# Altium schematic sheet [1] Power Circuit.SchDoc
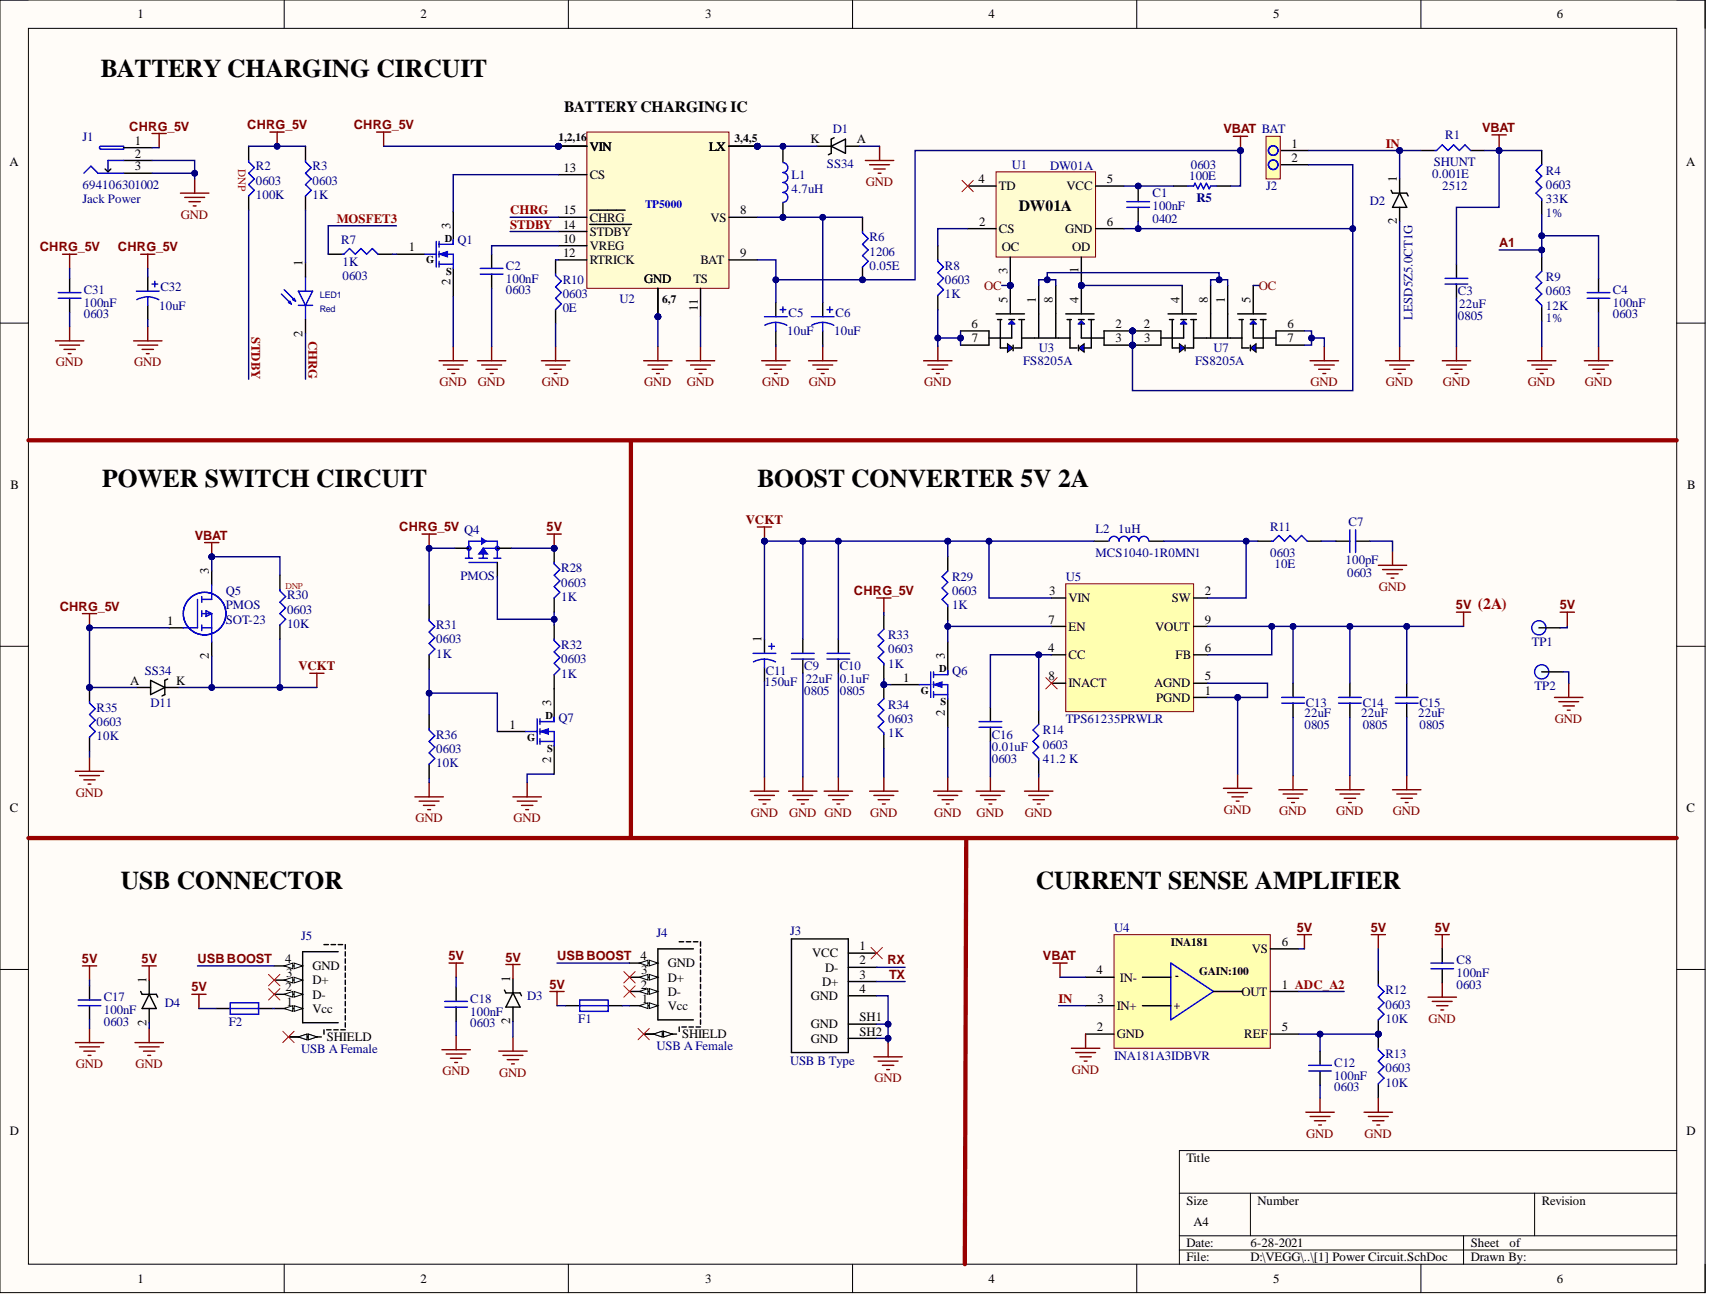
[diagram p0: [1] Power Circuit.SchDoc]

## Parts
C1  value 100nF  Case/Package: 0402  [CAPC0402]  (hidden comment: Cap)
C2  value 100nF  Case/Package: 0603  [CAPC0603]  (hidden comment: Cap)
C3  value 22uF  Case/Package: 0805  [CAPC0805_A14]  (hidden comment: Cap)
C4  value 100nF  Case/Package: 0603  [RESC0603]  (hidden comment: Cap)
C5  value 10uF  [CASE-A_3216]  (hidden comment: Cap Pol)
C6  value 10uF  [CASE-A_3216]  (hidden comment: Cap Pol)
C7  value 100pF  Case/Package: 0603  [RESC0603]  (hidden comment: Cap)
C8  value 100nF  Case/Package: 0603  [RESC0603]  (hidden comment: Cap)
C9  value 22uF  Case/Package: 0805  [CAPC0805_A14]  (hidden comment: Cap)
C10  value 0.1uF  Case/Package: 0805  [CAPC0805_A14]  (hidden comment: Cap)
C11  value 150uF  [CASE-D_7343]  (hidden comment: Tantalum capacitor)
C12  value 100nF  Case/Package: 0603  [RESC0603]  (hidden comment: Cap)
C13  value 22uF  Case/Package: 0805  [CAPC0805_A14]  (hidden comment: Cap)
C14  value 22uF  Case/Package: 0805  [CAPC0805_A14]  (hidden comment: Cap)
C15  value 22uF  Case/Package: 0805  [CAPC0805_A14]  (hidden comment: Cap)
C16  value 0.01uF  Case/Package: 0603  [CAPC0805_A14]  (hidden comment: Cap)
C17  value 100nF  Case/Package: 0603  [CAPC0603]  (hidden comment: Cap)
C18  value 100nF  Case/Package: 0603  [CAPC0603]  (hidden comment: Cap)
C31  value 100nF  Case/Package: 0603  [CAPC0603]  (hidden comment: Cap)
C32  value 10uF  [CASE-A_3216]  (hidden comment: Cap Pol)
D1  SS34  [SMA]
D2  LESD5Z5.0CT1G  [SOD-923]
D3  LESD5Z5.0CT1G  Operating Supply Voltage: 5V  [SOD-923]
D4  LESD5Z5.0CT1G  Operating Supply Voltage: 5V  [SOD-923]
D11  SS34  [SMA]
F1  SMD1206B200TF  [SMD1206B200TF]  (value not drawn)
F2  SMD1206B200TF  [SMD1206B200TF]  (value not drawn)
J1  Manufacturer Part Number: 694106301002  [12V_PWR JACK]  (hidden comment: JACK POWER)
J2  BAT  [HDR1X2H]  (no BOM)
J3  USB B Type  value USB Type B  [USB B TYPE]
J4  USB A Female  [USB A FEMALE]
J5  USB A Female  [USB A FEMALE]
L1  value 4.7uH  [SMD,6x6x4.2mm]  (hidden comment: Inductor)
L2  value 1uH  Manufacturer Part Number: MCS1040-1R0MN1  [10.0*11.5*4.0]  (hidden comment: Inductor)
LED1  Red  [LED-1 - horizontal]
Q1  MOSFET-N Si2302CDS  [SOT95P240X115-3N]  (value not drawn)
Q4  PMOS
Q5  PMOS  Case/Package: SOT-23  [SOT23-3]
Q6  MOSFET-N Si2302CDS  [SOT95P240X115-3N]  (value not drawn)
Q7  MOSFET-N Si2302CDS  [SOT95P240X115-3N]  (value not drawn)
R1  SHUNT  value 0.001E  [RESC2512]
R2  DNP  value 100K  Case/Package: 0603  [RESC0603]
R3  value 1K  Case/Package: 0603  [RESC0603]  (hidden comment: Res)
R4  value 33K  Case/Package: 0603  Parameter 1: 1%  [resc0603]  (hidden comment: Res)
R5  value 100E  Case/Package: 0603  [RESC0603]  (hidden comment: Res1)
R6  value 0.05E  Case/Package: 1206  [RESC1206]  (hidden comment: Res)
R7  value 1K  [RESC0603]  (hidden comment: Res)
R8  value 1K  Case/Package: 0603  [RESC0603]  (hidden comment: Res)
R9  value 12K  Case/Package: 0603  Parameter 1: 1%  [resc0603]  (hidden comment: Res)
R10  value 0E  Case/Package: 0603  [RESC0603]  (hidden comment: Res)
R11  value 10E  Case/Package: 0603  [RESC0603]  (hidden comment: Res)
R12  value 10K  Case/Package: 0603  [RESC0603]  (hidden comment: Res)
R13  value 10K  Case/Package: 0603  [RESC0603]  (hidden comment: Res)
R14  value 41.2 K  Case/Package: 0603  [RESC0603]  (hidden comment: Res)
R28  value 1K  Case/Package: 0603  [RESC0603]  (hidden comment: Res)
R29  value 1K  Case/Package: 0603  [RESC0603]  (hidden comment: Res)
R30  DNP  value 10K  Case/Package: 0603  [RESC0603]
R31  value 1K  Case/Package: 0603  [RESC0603]  (hidden comment: Res)
R32  value 1K  Case/Package: 0603  [RESC0603]  (hidden comment: Res)
R33  value 1K  Case/Package: 0603  [RESC0603]  (hidden comment: Res)
R34  value 1K  Case/Package: 0603  [RESC0603]  (hidden comment: Res)
R35  value 10K  Case/Package: 0603  [RESC0603]  (hidden comment: Res)
R36  value 10K  Case/Package: 0603  [RESC0603]  (hidden comment: Res)
TP1  TP  [TP-1]  (no BOM)
TP2  TP  [TP-1]  (no BOM)
U1  DW01A  [SOT-23-6]
U2  TP5000  [QFN-16_4x4x065P]
U3  FS8205A  [TSSOP8]
U4  INA181A3IDBVR  [SOT-23-6]
U5  TPS61235PRWLR  [TPS61235PRWLR]
U7  FS8205A  [TSSOP8]

## Nets
5V: C8.1 C13.2 C14.2 C15.2 C17.1 C18.1 D3.1 D4.1 F1.1 F2.1 Q4.S R12.1 R28.1 TP1.1 U4.6(VS) U5.6(FB) U5.9(VOUT)
CHRG_5V: C31.1 C32.1 D11.A J1.1 Q4.D Q5.1 R2.1 R3.1 R31.1 R33.2 R35.1 U2.1(VIN) U2.2 U2.16
GND: C2.2 C3.1 C4.2 C5.2 C6.2 C7.1 C8.2 C9.1 C10.1 C11.2 C12.2 C13.1 C14.1 C15.1 C16.1 C17.2 C18.2 C31.2 C32.2 D1.A D2.2 D3.2 D4.2 J1.2 J1.3 J3.4(GND) J3.SH1(GND) J3.SH2(GND) Q1.2 Q6.2 Q7.2 R8.2 R9.1 R10.2 R13.2 R14.1 R34.1 R35.2 R36.2 TP2.1 U2.6 U2.7 U2.11(TS) U3.6 U3.7 U4.2(GND) U5.1(PGND) U5.5(AGND) U7.6 U7.7
VBAT: C3.2 C5.1 Q5.3 R1.2 R4.2 R5.2 R6.2 R30.1 U2.9(BAT) U4.4(IN-)
VCKT: C9.2 C10.2 C11.1 D11.K L2.1 Q5.2 R29.2 R30.2 U5.3(VIN)
A1: C4.1 R4.1 R9.2
ADC_A2: U4.1(OUT)
CHRG: LED1.2 U2.15(CHRG)
IN: D2.1 J2.1 R1.1 U4.3(IN+)
MOSFET3: R7.1
OC: U1.3(OC) U3.5 U7.5
RX: J3.2(D-)
STDBY: R2.2 U2.14(STDBY)
TX: J3.3(D+)
USB BOOST: J4.4(GND) J5.4(GND)
NetC1_1: C1.1 R5.1 U1.5(VCC)
NetC1_2: C1.2 J2.2 U1.6(GND) U3.2 U3.3 U7.2 U7.3
NetC2_1: C2.1 U2.10(VREG)
NetC6_1: C6.1 L1.2 R6.1 U2.8(VS)
NetC7_2: C7.2 R11.2
NetC12_1: C12.1 R12.2 R13.1 U4.5(REF)
NetC16_2: C16.2 R14.2 U5.4(CC)
NetD1_K: D1.K L1.1 U2.3(LX) U2.4 U2.5
NetF1_2: F1.2 J4.1(Vcc)
NetF2_2: F2.2 J5.1(Vcc)
NetL2_2: L2.2 R11.1 U5.2(SW)
NetLED1_1: LED1.1 R3.2
NetQ1_1: Q1.1 R7.2
NetQ1_3: Q1.3 U2.13(CS)
NetQ4_G: Q4.G R28.2 R32.1
NetQ6_1: Q6.1 R33.1 R34.2
NetQ6_3: Q6.3 R29.1 U5.7(EN)
NetQ7_1: Q7.1 R31.2 R36.1
NetQ7_3: Q7.3 R32.2
NetR8_1: R8.1 U1.2(CS)
NetR10_1: R10.1 U2.12(RTRICK)
NetU1_1: U1.1(OD) U3.4 U7.4
NetU3_1: U3.1 U3.8 U7.1 U7.8

Not connected: J3 1(VCC); J4 2(D-) 3(D+) SHELL; J5 2(D-) 3(D+) SHELL; U1 4(TD); U5 8(INACT)

## Library data of the parts
C1 = Cap: Ceramic Capacitor,100nF,0402; Manufacturer Part Number: 04025C104KAT2A; Component Kind: Standard; Library Name: CUSTOM TNY290PG.SchLib; Signal Integrity: Cap Pol; Simulation: CAP
C2 C4 C8 C12 C17 C18 C31 = Cap: Ceramic Capacitor,100nF,10V,0603; Manufacturer Part Number: CC0603KRX7R6BB104; Component Kind: Standard; ComponentLink1Description: http://www.farnell.com/datasheets/2739827.pdf?src-supplier=Element14; ComponentLink1URL: http://www.farnell.com/datasheets/2739827.pdf?src-supplier=Element14; ComponentLink2Description: http://www.farnell.com/datasheets/40889.pdf?src-supplier=Element14; ComponentLink2URL: http://www.farnell.com/datasheets/40889.pdf?src-supplier=Element14; Library Name: CUSTOM TNY290PG.SchLib; Signal Integrity: Cap Pol; Simulation: CAP
C3 C9 C13-C15 = Cap: Ceramic Capacitor,22uF,10V,0805,X5R; Manufacturer Part Number: 0805X226M100NT; Component Kind: Standard; ComponentLink1Description: http://www.farnell.com/datasheets/2739827.pdf?src-supplier=Element14; ComponentLink1URL: http://www.farnell.com/datasheets/2739827.pdf?src-supplier=Element14; ComponentLink2Description: http://www.farnell.com/datasheets/40889.pdf?src-supplier=Element14; ComponentLink2URL: http://www.farnell.com/datasheets/40889.pdf?src-supplier=Element14; Library Name: CUSTOM TNY290PG.SchLib; Signal Integrity: Cap Pol; Simulation: CAP
C5 C6 C32 = Cap Pol: Tantalum capacitor 10uF 10V, CASE-A_3216; Manufacturer Part Number: CA45-106K010AT; Case/Package: CASE-A_3216; Component Kind: Standard; Library Name: CUSTOM TNY290PG.SchLib; Signal Integrity: Cap Pol; Simulation: CAP
C7 = Cap: Ceramic Capacitor,100pF,50V,C0G/NP0,0603; Manufacturer Part Number: CGA3E2C0G1H101JT0Y0N; Component Kind: Standard; ComponentLink1Description: http://www.farnell.com/datasheets/2739827.pdf?src-supplier=Element14; ComponentLink1URL: http://www.farnell.com/datasheets/2739827.pdf?src-supplier=Element14; ComponentLink2Description: http://www.farnell.com/datasheets/40889.pdf?src-supplier=Element14; ComponentLink2URL: http://www.farnell.com/datasheets/40889.pdf?src-supplier=Element14; Library Name: CUSTOM TNY290PG.SchLib; Signal Integrity: Cap Pol; Simulation: CAP
C10 = Cap: Ceramic Capacitor,0.1uF,25V,0805,X5R; Manufacturer Part Number: 0402X104K250CT; Component Kind: Standard; ComponentLink1Description: http://www.farnell.com/datasheets/2739827.pdf?src-supplier=Element14; ComponentLink1URL: http://www.farnell.com/datasheets/2739827.pdf?src-supplier=Element14; ComponentLink2Description: http://www.farnell.com/datasheets/40889.pdf?src-supplier=Element14; ComponentLink2URL: http://www.farnell.com/datasheets/40889.pdf?src-supplier=Element14; Library Name: CUSTOM TNY290PG.SchLib; Signal Integrity: Cap Pol; Simulation: CAP
C11 = Cap Pol: Tantalum capacitor 150uF 10V,  CASE-D_7343; Manufacturer Part Number: T495D157K010ATE100/TPSC157K010R0150; Case/Package: CASE-D_7343; Component Kind: Standard; Library Name: CUSTOM TNY290PG.SchLib; Signal Integrity: Cap Pol; Simulation: CAP
C16 = Cap: Ceramic Capacitor,0.01uF,6.3V,0603,X5R; Component Kind: Standard; ComponentLink1Description: http://www.farnell.com/datasheets/2739827.pdf?src-supplier=Element14; ComponentLink1URL: http://www.farnell.com/datasheets/2739827.pdf?src-supplier=Element14; ComponentLink2Description: http://www.farnell.com/datasheets/40889.pdf?src-supplier=Element14; ComponentLink2URL: http://www.farnell.com/datasheets/40889.pdf?src-supplier=Element14; Library Name: CUSTOM TNY290PG.SchLib; Signal Integrity: Cap Pol; Simulation: CAP
D1 D11 = SS34: 40V 3A 550mV @ 3A SMA Schottky Barrier Diodes (SBD) RoHS; Case/Package: SMA; Component Kind: Standard; ComponentLink1Description: https://datasheet.ciiva.com/30478/0900766b812769b5-30478676.pdf?src-supplier=RSComponents; ComponentLink1URL: https://datasheet.ciiva.com/30478/0900766b812769b5-30478676.pdf?src-supplier=RSComponents; ComponentLink2Description: https://datasheet.ciiva.com/25958/esd9x3-3st5g-d-25958458.pdf?src-supplier=Avnet+Europe; ComponentLink2URL: https://datasheet.ciiva.com/25958/esd9x3-3st5g-d-25958458.pdf?src-supplier=Avnet+Europe; ComponentLink3Description: https://datasheet.ciiva.com/1219/1748783-1219324.pdf?src-supplier=Newark; ComponentLink3URL: https://datasheet.ciiva.com/1219/1748783-1219324.pdf?src-supplier=Newark; ComponentLink4Description: https://datasheet.ciiva.com/3304/esd9x3-3st5g-d-3304012.pdf?src-supplier=Avnet; ComponentLink4URL: https://datasheet.ciiva.com/3304/esd9x3-3st5g-d-3304012.pdf?src-supplier=Avnet; ComponentLink5Description: https://datasheet.ciiva.com/339/esd9x3-3st5g-d-339709.pdf?src-supplier=Digi-Key; ComponentLink5URL: https://datasheet.ciiva.com/339/esd9x3-3st5g-d-339709.pdf?src-supplier=Digi-Key; ComponentLink6Description: https://datasheet.ciiva.com/16215/esd9x3-3st5g-d-16215149.pdf?src-supplier=Future+Electronics; ComponentLink6URL: https://datasheet.ciiva.com/16215/esd9x3-3st5g-d-16215149.pdf?src-supplier=Future+Electronics; ComponentLink7Description: https://datasheet.ciiva.com/339/esd9x3-3st5g-d-339710.pdf?src-supplier=Digi-Key; ComponentLink7URL: https://datasheet.ciiva.com/339/esd9x3-3st5g-d-339710.pdf?src-supplier=Digi-Key; ComponentLink8Description: https://datasheet.ciiva.com/339/esd9x3-3st5g-d-339711.pdf?src-supplier=Digi-Key; ComponentLink8URL: https://datasheet.ciiva.com/339/esd9x3-3st5g-d-339711.pdf?src-supplier=Digi-Key; Library Name: CUSTOM TNY290PG.SchLib; Manufacturer Part Number: SS34; Value: SS34
D2 = ESD9X5.0ST5G: 15V Clamp 5A (8/20µs) Ipp Tvs Diode Surface Mount SOD-523; Manufacturer: ON Semiconductor; Breakdown Voltage: 6.2V; Capacitance: 65pF; Clamping Voltage: 12.3V; Direction: Unidirectional; Element Configuration: Single; ESD Protection: Yes; Leakage Current: 1uA; Max Power Dissipation: 150mW; Max Surge Current: 8.7A; Number of Channels: 1; Operating Supply Voltage: 5V; Peak Pulse Power: 107W; Power Line Protection: No; Test Current: 1mA; Case/Package: SOD-523-2; Category: ESD and Circuit Protection ICs; Component Kind: Standard; ComponentLink1Description: https://datasheet.ciiva.com/30478/0900766b812769b5-30478676.pdf?src-supplier=RSComponents; ComponentLink1URL: https://datasheet.ciiva.com/30478/0900766b812769b5-30478676.pdf?src-supplier=RSComponents; ComponentLink2Description: https://datasheet.ciiva.com/25958/esd9x3-3st5g-d-25958458.pdf?src-supplier=Avnet+Europe; ComponentLink2URL: https://datasheet.ciiva.com/25958/esd9x3-3st5g-d-25958458.pdf?src-supplier=Avnet+Europe; ComponentLink3Description: https://datasheet.ciiva.com/1219/1748783-1219324.pdf?src-supplier=Newark; ComponentLink3URL: https://datasheet.ciiva.com/1219/1748783-1219324.pdf?src-supplier=Newark; ComponentLink4Description: https://datasheet.ciiva.com/3304/esd9x3-3st5g-d-3304012.pdf?src-supplier=Avnet; ComponentLink4URL: https://datasheet.ciiva.com/3304/esd9x3-3st5g-d-3304012.pdf?src-supplier=Avnet; ComponentLink5Description: https://datasheet.ciiva.com/339/esd9x3-3st5g-d-339709.pdf?src-supplier=Digi-Key; ComponentLink5URL: https://datasheet.ciiva.com/339/esd9x3-3st5g-d-339709.pdf?src-supplier=Digi-Key; ComponentLink6Description: https://datasheet.ciiva.com/16215/esd9x3-3st5g-d-16215149.pdf?src-supplier=Future+Electronics; ComponentLink6URL: https://datasheet.ciiva.com/16215/esd9x3-3st5g-d-16215149.pdf?src-supplier=Future+Electronics; ComponentLink7Description: https://datasheet.ciiva.com/339/esd9x3-3st5g-d-339710.pdf?src-supplier=Digi-Key; ComponentLink7URL: https://datasheet.ciiva.com/339/esd9x3-3st5g-d-339710.pdf?src-supplier=Digi-Key; ComponentLink8Description: https://datasheet.ciiva.com/339/esd9x3-3st5g-d-339711.pdf?src-supplier=Digi-Key; ComponentLink8URL: https://datasheet.ciiva.com/339/esd9x3-3st5g-d-339711.pdf?src-supplier=Digi-Key; Composition: Zener; Contact Plating: Tin,Matte; Depth: 0.6mm; Halogen Free: Halogen Free; Height: 0.4mm; Lead Free: Lead Free; Length: 0.8mm; Library Name: CUSTOM TNY290PG.SchLib; Manufacturer Part Number: LESD5Z5.0CT1G; Max Breakdown Voltage: 6.2V; Max Operating Temperature: 150°C; Max Reverse Leakage Current: 1uA; Min Breakdown Voltage: 6.2V; Min Operating Temperature: -55°C; Number of Elements: 1; Number of Lines: 1; Number of Pins: 2; Number of Unidirectional Channels: 1; Package Quantity: 1; Packaging: Tape and Reel; Peak Pulse Current: 8.7A; Polarity: Unidirectional; Pricing 1: 1=0.14000, 10=0.12700, 25=0.12120, 100=0.05340, 250=0.05120, 500=0.04190, 1000=0.02910, 2500=0.02542 (USD); Radiation Hardening: No; REACH SVHC: No SVHC; Reverse Breakdown Voltage: 6.2V; Reverse Standoff Voltage: 5V; RoHS: Yes; Stock 1: 835133; Supplier 1: Digi-Key; Supplier Part Number 1: ESD9X5.0ST5GOSCT-ND; Termination: SMD/SMT; Width: 0.65mm; Working Voltage: 5V
D3 D4 = ESD9X5.0ST5G: 15V Clamp 5A (8/20µs) Ipp Tvs Diode Surface Mount SOD-523; Manufacturer: ON Semiconductor; Breakdown Voltage: 6.2V; Capacitance: 65pF; Clamping Voltage: 12.3V; Direction: Unidirectional; Element Configuration: Single; ESD Protection: Yes; Leakage Current: 1uA; Max Power Dissipation: 150mW; Max Surge Current: 8.7A; Number of Channels: 1; Peak Pulse Power: 107W; Power Line Protection: No; Test Current: 1mA; Case/Package: SOD-523-2; Category: ESD and Circuit Protection ICs; Component Kind: Standard; ComponentLink1Description: https://datasheet.ciiva.com/30478/0900766b812769b5-30478676.pdf?src-supplier=RSComponents; ComponentLink1URL: https://datasheet.ciiva.com/30478/0900766b812769b5-30478676.pdf?src-supplier=RSComponents; ComponentLink2Description: https://datasheet.ciiva.com/25958/esd9x3-3st5g-d-25958458.pdf?src-supplier=Avnet+Europe; ComponentLink2URL: https://datasheet.ciiva.com/25958/esd9x3-3st5g-d-25958458.pdf?src-supplier=Avnet+Europe; ComponentLink3Description: https://datasheet.ciiva.com/1219/1748783-1219324.pdf?src-supplier=Newark; ComponentLink3URL: https://datasheet.ciiva.com/1219/1748783-1219324.pdf?src-supplier=Newark; ComponentLink4Description: https://datasheet.ciiva.com/3304/esd9x3-3st5g-d-3304012.pdf?src-supplier=Avnet; ComponentLink4URL: https://datasheet.ciiva.com/3304/esd9x3-3st5g-d-3304012.pdf?src-supplier=Avnet; ComponentLink5Description: https://datasheet.ciiva.com/339/esd9x3-3st5g-d-339709.pdf?src-supplier=Digi-Key; ComponentLink5URL: https://datasheet.ciiva.com/339/esd9x3-3st5g-d-339709.pdf?src-supplier=Digi-Key; ComponentLink6Description: https://datasheet.ciiva.com/16215/esd9x3-3st5g-d-16215149.pdf?src-supplier=Future+Electronics; ComponentLink6URL: https://datasheet.ciiva.com/16215/esd9x3-3st5g-d-16215149.pdf?src-supplier=Future+Electronics; ComponentLink7Description: https://datasheet.ciiva.com/339/esd9x3-3st5g-d-339710.pdf?src-supplier=Digi-Key; ComponentLink7URL: https://datasheet.ciiva.com/339/esd9x3-3st5g-d-339710.pdf?src-supplier=Digi-Key; ComponentLink8Description: https://datasheet.ciiva.com/339/esd9x3-3st5g-d-339711.pdf?src-supplier=Digi-Key; ComponentLink8URL: https://datasheet.ciiva.com/339/esd9x3-3st5g-d-339711.pdf?src-supplier=Digi-Key; Composition: Zener; Contact Plating: Tin,Matte; Depth: 0.6mm; Halogen Free: Halogen Free; Height: 0.4mm; Lead Free: Lead Free; Length: 0.8mm; Library Name: CUSTOM TNY290PG.SchLib; Manufacturer Part Number: LESD5Z5.0CT1G; Max Breakdown Voltage: 6.2V; Max Operating Temperature: 150°C; Max Reverse Leakage Current: 1uA; Min Breakdown Voltage: 6.2V; Min Operating Temperature: -55°C; Number of Elements: 1; Number of Lines: 1; Number of Pins: 2; Number of Unidirectional Channels: 1; Package Quantity: 1; Packaging: Tape and Reel; Peak Pulse Current: 8.7A; Polarity: Unidirectional; Pricing 1: 1=0.14000, 10=0.12700, 25=0.12120, 100=0.05340, 250=0.05120, 500=0.04190, 1000=0.02910, 2500=0.02542 (USD); Radiation Hardening: No; REACH SVHC: No SVHC; Reverse Breakdown Voltage: 6.2V; Reverse Standoff Voltage: 5V; RoHS: Yes; Stock 1: 835133; Supplier 1: Digi-Key; Supplier Part Number 1: ESD9X5.0ST5GOSCT-ND; Termination: SMD/SMT; Width: 0.65mm; Working Voltage: 5V
F1 F2 = FUSE: 6V 3.5A 1.5s SMD PTC Resettable Fuses RoHS; Case/Package: SMD; Component Kind: Standard; Library Name: Schlib1.SchLib; Manufacturer Part Number: SMD1206B200TF
J1 = JACK POWER: DC Power Connectors WR-DC Right Angled; Manufacturer: Wurth Electronics; Current Rating: 5A; Gender: Male; Insulation Resistance: 100MR; Shielding: Unshielded; Voltage Rating: 24V; Voltage Rating (DC): 20V; Case/Package: TH; Color: Black; Component Kind: Standard; ComponentLink1Description: https://katalog.we-online.de/em/datasheet/6941xx301002.pdf?src-supplier=Digi-Key; ComponentLink1URL: https://katalog.we-online.de/em/datasheet/6941xx301002.pdf?src-supplier=Digi-Key; ComponentLink2Description: http://www.farnell.com/cad/2130967.pdf?src-supplier=Element14; ComponentLink2URL: http://www.farnell.com/cad/2130967.pdf?src-supplier=Element14; ComponentLink3Description: http://www.mouser.com/ds/2/445/6941xx301002-469315.pdf?src-supplier=Mouser; ComponentLink3URL: http://www.mouser.com/ds/2/445/6941xx301002-469315.pdf?src-supplier=Mouser; Connector Type: Jack Power; Contact Gender: Female; Contact Material: Copper Alloy; Contact Plating: Tin; Flammability Rating: UL94 V-0; Housing Material: Polyamide; Library Name: CUSTOM TNY290PG.SchLib; Max Operating Temperature: 85°C; Min Operating Temperature: -40°C; Mount: Through Hole; Package Quantity: 500; Packaging: Bulk; Pricing 1: 1=0.96, 10=0.908, 50=0.811, 100=0.746, 500=0.648, 1000=0.551 (USD); REACH SVHC: Unknown; Stock 1: 3331; Supplier 1: Digi-Key; Supplier Part Number 1: 732-5930-ND; Termination: Through Hole
J2 = Header 2 pin: Library Name: CUSTOM TNY290PG.SchLib
J3 = USB B Type: USB B-type Female Right Angle PCB Mount; Case/Package: TH; Component Kind: Standard; Library Name: CUSTOM TNY290PG.SchLib; Manufacturer Part Number: Sunrom 3966
J4 J5 = USB A Female: USB-A (USB TYPE-A) USB 2.0 Receptacle Connector 4 Position Through Hole, Right Angle; Manufacturer: TE Connectivity; Manufacturer Part Number: 292303-1; Circuit Application: Signal; Connector System: Cable-to-Board; Contact Current Rating: 1A; Insulation Resistance: 1GR; Number of Contacts: 4; Number of Ports: 1; PCB Thickness: 0.062inch; Pitch: 2.5mm; Plating: Bright,Tin; Plating Thickness: 0.76um; Tail Length: 0.112inch; USB Standard: USB 2.0; Voltage Rating (AC): 30V; Case/Package: TH; Category: Audio / Video Connectors; Color: Black; Component Kind: Standard; ComponentLink1Description: https://datasheet.ciiva.com/851/eng-cd-292303-e9-851601.pdf?src-supplier=Farnell; ComponentLink1URL: https://datasheet.ciiva.com/851/eng-cd-292303-e9-851601.pdf?src-supplier=Farnell; ComponentLink2Description: https://datasheet.ciiva.com/1099/1653030-1099765.pdf?src-supplier=Newark; ComponentLink2URL: https://datasheet.ciiva.com/1099/1653030-1099765.pdf?src-supplier=Newark; ComponentLink3Description: https://datasheet.ciiva.com/1098/eng-cd-292303-e9-1098298.pdf?src-supplier=Element14; ComponentLink3URL: https://datasheet.ciiva.com/1098/eng-cd-292303-e9-1098298.pdf?src-supplier=Element14; ComponentLink4Description: https://datasheet.ciiva.com/11731/0900766b806d08c4-11731322.pdf?src-supplier=RSComponents; ComponentLink4URL: https://datasheet.ciiva.com/11731/0900766b806d08c4-11731322.pdf?src-supplier=RSComponents; ComponentLink5Description: https://datasheet.ciiva.com/14103/04270708-14103420.pdf?src-supplier=Distrelec; ComponentLink5URL: https://datasheet.ciiva.com/14103/04270708-14103420.pdf?src-supplier=Distrelec; ComponentLink6Description: https://datasheet.ciiva.com/19454/921651-19454494.pdf?src-supplier=Jameco; ComponentLink6URL: https://datasheet.ciiva.com/19454/921651-19454494.pdf?src-supplier=Jameco; ComponentLink7Description: https://datasheet.ciiva.com/16308/eng-cd-292303-e7-16308319.pdf?src-supplier=Future+Electronics; ComponentLink7URL: https://datasheet.ciiva.com/16308/eng-cd-292303-e7-16308319.pdf?src-supplier=Future+Electronics; ComponentLink8Description: https://datasheet.ciiva.com/3094/eng-ds-1-1773453-6-0908-3094357.pdf?src-supplier=Avnet; ComponentLink8URL: https://datasheet.ciiva.com/3094/eng-ds-1-1773453-6-0908-3094357.pdf?src-supplier=Avnet; ComponentLink9Description: https://datasheet.ciiva.com/16468/eng-ds-1-1773453-6-0908-16468232.pdf?src-supplier=Avnet+Asia; ComponentLink9URL: https://datasheet.ciiva.com/16468/eng-ds-1-1773453-6-0908-16468232.pdf?src-supplier=Avnet+Asia; ComponentLink10Description: https://datasheet.ciiva.com/851/eng-cd-292303-e9-851082.pdf?src-supplier=Digi-Key; ComponentLink10URL: https://datasheet.ciiva.com/851/eng-cd-292303-e9-851082.pdf?src-supplier=Digi-Key; ComponentLink11Description: https://datasheet.ciiva.com/8016/1653030-8016986.pdf?src-supplier=Element14; ComponentLink11URL: https://datasheet.ciiva.com/8016/1653030-8016986.pdf?src-supplier=Element14; ComponentLink12Description: https://datasheet.ciiva.com/14618/70041246-14618698.pdf?src-supplier=Allied+Electronics; ComponentLink12URL: https://datasheet.ciiva.com/14618/70041246-14618698.pdf?src-supplier=Allied+Electronics; ComponentLink13Description: https://datasheet.ciiva.com/25907/eng-ds-1-1773453-6-0908-25907515.pdf?src-supplier=Avnet+Europe; ComponentLink13URL: https://datasheet.ciiva.com/25907/eng-ds-1-1773453-6-0908-25907515.pdf?src-supplier=Avnet+Europe; ComponentLink14Description: https://datasheet.ciiva.com/30148/ddecontrolleractionsrchrtrvdocnm117734536doctypedsdoclan-30148862.pdf?src-supplier=Onlinecomponents.com; ComponentLink14URL: https://datasheet.ciiva.com/30148/ddecontrolleractionsrchrtrvdocnm117734536doctypedsdoclan-30148862.pdf?src-supplier=Onlinecomponents.com; Connector Type: Receptacle; Contact Material: Copper Alloy,Copper; Contact Plating: Gold; Current Rating: 1A; Depth: 14mm; Flammability Rating: UL94 V-0; Gender: Receptacle; Halogen Free: Halogen Free; Housing Color: Black; Housing Material: Thermoplastic; Length: 14.63mm; Library Name: CUSTOM TNY290PG.SchLib; Max Operating Temperature: 185°C; Min Operating Temperature: -67°C; Mount: Through Hole,Horizontal; Number of Positions: 4; Number of Terminals: 4; Orientation: Right Angle; Package Quantity: 30; Packaging: Rail/Tube; Pricing 1: 1=1.49000, 10=1.35400, 25=1.27160, 50=1.21620, 100=1.16100, 250=1.05040, 500=0.96748, 1000=0.82926, 2500=0.77398 (USD); REACH SVHC: No SVHC; RoHS: Yes; Stock 1: 16288; Supplier 1: Digi-Key; Supplier Part Number 1: A31726-ND; Termination: Through Hole
L1 = Inductor: 4.7uH ±20% 4A 26mΩ SMD,6x6x4.2mm Power Inductors RoHS; Manufacturer Part Number: HPC6045NF-4R7MTH; Case/Package: SMD,6x6x4.2mm; Component Kind: Standard; Library Name: CUSTOM TNY290PG.SchLib
L2 = Inductor: 1uH ±20% 18A 3mΩ 10.0*11.5*4.0 Power Inductors RoHS; Case/Package: 10.0*11.5*4.0; Component Kind: Standard; ComponentLink1Description: https://www.bourns.com/docs/Product-Datasheets/SRN6045.pdf?src-supplier=Digi-Key; ComponentLink1URL: https://www.bourns.com/docs/Product-Datasheets/SRN6045.pdf?src-supplier=Digi-Key; ComponentLink2Description: http://www.mouser.com/ds/2/54/rn6045-778135.pdf?src-supplier=Mouser; ComponentLink2URL: http://www.mouser.com/ds/2/54/rn6045-778135.pdf?src-supplier=Mouser; ComponentLink3Description: https://www.alliedelec.com/m/d/c2606704ad1546769e4d3e48849a9ce6.pdf?src-supplier=Allied+Electronics; ComponentLink3URL: https://www.alliedelec.com/m/d/c2606704ad1546769e4d3e48849a9ce6.pdf?src-supplier=Allied+Electronics; ComponentLink4Description: http://uk.rs-online.com/webdocs/0fe1/0900766b80fe1f3e.pdf?src-supplier=RSComponents; ComponentLink4URL: http://uk.rs-online.com/webdocs/0fe1/0900766b80fe1f3e.pdf?src-supplier=RSComponents; ComponentLink5Description: http://www.farnell.com/datasheets/1875285.pdf?src-supplier=Element14; ComponentLink5URL: http://www.farnell.com/datasheets/1875285.pdf?src-supplier=Element14; ComponentLink6Description: http://www.produktinfo.conrad.com/datenblaetter/1000000-1099999/001056444-da-01-en-MAG_ABG_LEIST_IND_SRN6045_1R0Y_1_0UH_SMD.pdf?src-supplier=Conrad; ComponentLink6URL: http://www.produktinfo.conrad.com/datenblaetter/1000000-1099999/001056444-da-01-en-MAG_ABG_LEIST_IND_SRN6045_1R0Y_1_0UH_SMD.pdf?src-supplier=Conrad; Library Name: CUSTOM TNY290PG.SchLib
LED1 = LED2: LED, Red, Untinted, Diffused; Manufacturer Part Number: KP DELPS1.FP-UGVI-34-Z555; Case/Package: TH; Component Kind: Standard; Stock 1: 0; Supplier 1: Mouser; Supplier Part Number 1: 720-KPDELPS1FPA3998
Q1 Q6 Q7 = MOSFET-N Si2302CDS: N-Channel 20V 3A 1.25W (Ta) Surface Mount SOT-23; Manufacturer: MCC; Manufacturer Part Number: SI2302-TP; Drain to Source Resistance: 110mR; Gate to Source Voltage (Vgs): 8V; Input Capacitance: 237pF; Number of Elements: 1; Rds On Max: 72mR; Case/Package: SOT-23; Component Kind: Standard; ComponentLink1Description: http://www.vishay.com/docs/68645/si2302cd.pdf?src-supplier=Avnet; ComponentLink1URL: http://www.vishay.com/docs/68645/si2302cd.pdf?src-supplier=Avnet; ComponentLink2Description: http://www.farnell.com/datasheets/1719572.pdf?src-supplier=Element14; ComponentLink2URL: http://www.farnell.com/datasheets/1719572.pdf?src-supplier=Element14; ComponentLink3Description: http://www.vishay.com/docs/68645/si2302cd.pdf?src-supplier=Future+Electronics; ComponentLink3URL: http://www.vishay.com/docs/68645/si2302cd.pdf?src-supplier=Future+Electronics; ComponentLink4Description: http://www.vishay.com/docs/68645/si2302cds.pdf?src-supplier=Digi-Key; ComponentLink4URL: http://www.vishay.com/docs/68645/si2302cds.pdf?src-supplier=Digi-Key; ComponentLink5Description: http://www.mccsemi.com/up_pdf/SI2302(SOT-23).pdf?src-supplier=Digi-Key; ComponentLink5URL: http://www.mccsemi.com/up_pdf/SI2302(SOT-23).pdf?src-supplier=Digi-Key; Continuous Drain Current (ID): 3A; Drain to Source Breakdown Voltage: 20V; Drain to Source Voltage (Vdss): 20V; Lead Free: Lead Free; Library Name: Miscellaneous Devices.SchLib; Max Operating Temperature: 150°C; Max Power Dissipation: 1.25W; Min Operating Temperature: -55°C; Mount: Surface Mount; Number of Pins: 3; Package Quantity: 3000; Packaging: Tape and Reel; Power Dissipation: 1.25W; Pricing 1: 1=0.45, 10=0.386, 100=0.288, 500=0.226, 1000=0.175, 3000=0.145 (USD); Simulation: NMOS; Stock 1: 29970; Supplier 1: Mouser; Supplier Part Number 1: 833-SI2302-TP
Q4 = MOSFET_P_CH: 12V 8A 1.2V @ 250uA 18mΩ @ 8A; Manufacturer Part Number: HSS2333; Case/Package: SOT-23; Component Kind: Standard; Library Name: ATMEGA328P.SCHLIB
Q5 = P_MOS_WPM2015-3/TR: 12V 8A 1.2V @ 250uA 18mΩ @ 8A; Manufacturer Part Number: HSS2333; Component Kind: Standard; Library Name: VEGG_Schlib.SchLib
R1 = Res: Thick Film Resistor - 0.001ohm, 1%, 2512; Manufacturer Part Number: LR2512D-2W-1mR-1%; Case/Package: 2512; Component Kind: Standard; Library Name: CUSTOM TNY290PG.SchLib
R2 = Res: Thick Film Resistor -  100Kohm, 1%, 0603; Manufacturer Part Number: RS-03K1001FT; Component Kind: Standard; Library Name: CUSTOM TNY290PG.SchLib
R3 R7 R8 R28 R29 R31-R34 = Res: Thick Film Resistor -  1Kohm, 1%, 0603; Manufacturer Part Number: RS-03K1001FT; Component Kind: Standard; Library Name: CUSTOM TNY290PG.SchLib
  R7: Case/Package: 0603
R4 = Res: Thick Film Resistor -  33Kohm, 1%, 0603; Manufacturer Part Number: RC0603FR-0733KL; Component Kind: Standard; Library Name: CUSTOM TNY290PG.SchLib
R5 = Res1: Thick Film Resistor - 100ohm, 1%, 0603; Component Kind: Standard; Library Name: Miscellaneous Devices.IntLib
R6 = Res: Thick Film Resistor - 0.05ohm, 1%, 1206; Manufacturer Part Number: CR1206FR050P05R; Component Kind: Standard; Library Name: CUSTOM TNY290PG.SchLib
R9 = Res: Thick Film Resistor -  12Kohm, 1%, 0603; Manufacturer Part Number: RS-03K123JT; Component Kind: Standard; Library Name: CUSTOM TNY290PG.SchLib
R10 = Res: Thick Film Resistor -  0 ohm, 1%, 0603; Manufacturer Part Number: WR06X000 PTL; Component Kind: Standard; Library Name: CUSTOM TNY290PG.SchLib
R11 = Res: Thick Film Resistor - 10ohm, 1%, 0603; Manufacturer Part Number: RS-03K100JT; Component Kind: Standard; Library Name: CUSTOM TNY290PG.SchLib
R12 R13 R30 R35 R36 = Res: Thick Film Resistor -  10Kohm, 1%, 0603; Manufacturer Part Number: RK73B1JTTD103J; Component Kind: Standard; Library Name: CUSTOM TNY290PG.SchLib
R14 = Res: Thick Film Resistor - 41.2k ohm, 1%, 0603; Manufacturer Part Number: RC0603FR-1041K2L; Component Kind: Standard; Library Name: CUSTOM TNY290PG.SchLib
U1 = DW01A: DW01A battery protection IC SOT-23-6 (Sunrom Product Code 4911); Case/Package: SOT-23-6; Component Kind: Standard; Library Name: CUSTOM TNY290PG.SchLib; Manufacturer Part Number: DW01A
U2 = TP5000: QFN-16_4x4x065P PMIC - Battery Management RoHS; Manufacturer Part Number: TP5000-QFN16; Case/Package: QFN-16_4x4x065P; Component Kind: Standard; Library Name: CUSTOM TNY290PG.SchLib
U3 U7 = FS8205A: Dual N-Channel Mosfet TSSOP-8 (Sunrom Product Code 5292); Value: 5.0A; Case/Package: TSSOP-8; Component Kind: Standard; Library Name: CUSTOM TNY290PG.SchLib; Manufacturer Part Number: FS8205A
U4 = INA181A3IDBVR: Automotive, 26V bi-directional current sense amplifier for cost-sensitive systems 6-SOT-23 -40 to 125; Manufacturer: Texas Instruments; -3db Bandwidth: 350kHz; Drain to Source Voltage (Vdss): 60V; FET Type: 2 N-Channel; Input Capacitance: 4.84nF; Max Power Dissipation: 12W; Number of Circuits: 1; Operating Supply Current: 260uA; Rds On Max: 2.1mR; Slew Rate: 2V/µs; Case/Package: SOT-23-6; Category: Amplifiers - Op Amps, Buffer, Instrumentation; Component Kind: Standard; ComponentLink1Description: http://www.ti.com/lit/ds/symlink/ina181.pdf?src-supplier=Digi-Key; ComponentLink1URL: http://www.ti.com/lit/ds/symlink/ina181.pdf?src-supplier=Digi-Key; Library Name: CUSTOM TNY290PG.SchLib; Manufacturer Part Number: INA181A3IDBVR; Max Operating Temperature: 125°C; Min Operating Temperature: -40°C; Mount: Surface Mount; Number of Pins: 22; Packaging: Cut Tape; Pricing 1: 1=0.44000, 10=0.37500, 25=0.35000, 100=0.26000, 250=0.22000, 500=0.21000, 1000=0.15500 (USD); RoHS: No; Stock 1: 8626; Supplier 1: Digi-Key; Supplier Part Number 1: 296-47658-1-ND
U5 = TPS61235PRWLR: 8-A Valley Current Synchronous Boost Converters with Constant Current Output; Manufacturer 1: Texas Instruments; Max Input Voltage: 4.5V; Min Input Voltage: 2.3V; Min Output Voltage: 5.1V; Number of Outputs: 1; Output Configuration: Positive; Output Current: 6.5A; Output Type: Fixed; Quiescent Current: 10uA; Switching Frequency: 1MHz; Synchronous Rectifier: Yes; Case/Package: VQFN-9; Category: Power Supply Modules; Component Kind: Standard; ComponentLink1Description: http://www.ti.com/lit/pdf/slyt522?src-supplier=Digi-Key; ComponentLink1URL: http://www.ti.com/lit/pdf/slyt522?src-supplier=Digi-Key; ComponentLink2Description: http://www.ti.com/lit/ds/symlink/tps61235p.pdf?src-supplier=Digi-Key; ComponentLink2URL: http://www.ti.com/lit/ds/symlink/tps61235p.pdf?src-supplier=Digi-Key; ComponentLink3Description: http://www.ti.com/general/docs/suppproductinfo.tsp?distId=10&amp;gotoUrl=http%3A%2F%2Fwww.ti.com%2Flit%2Fgpn%2Ftps61235p&src-supplier=Digi-Key; ComponentLink3URL: http://www.ti.com/general/docs/suppproductinfo.tsp?distId=10&amp;gotoUrl=http%3A%2F%2Fwww.ti.com%2Flit%2Fgpn%2Ftps61235p&src-supplier=Digi-Key; Lead Free: Lead Free; Library Name: CUSTOM TNY290PG.SchLib; Manufacturer Part Number: TPS61235PRWLR; Max Operating Temperature: 85°C; Min Operating Temperature: -40°C; Mount: Surface Mount; Number of Pins: 9; Packaging: Tape and Reel; Pricing 1: 1=2.02000, 10=1.81800, 25=1.71520, 100=1.37200, 250=1.20052, 500=1.16620, 1000=0.92610 (USD); RoHS: No; Stock 1: 3709; Supplier 1: Digi-Key; Supplier Part Number 1: 296-47376-1-ND; Topology: Boost
TP1 TP2 = TP: Description: TP; Library Name: CUSTOM TNY290PG.SchLib
